# Altium schematic sheet Power.SchDoc(Power)
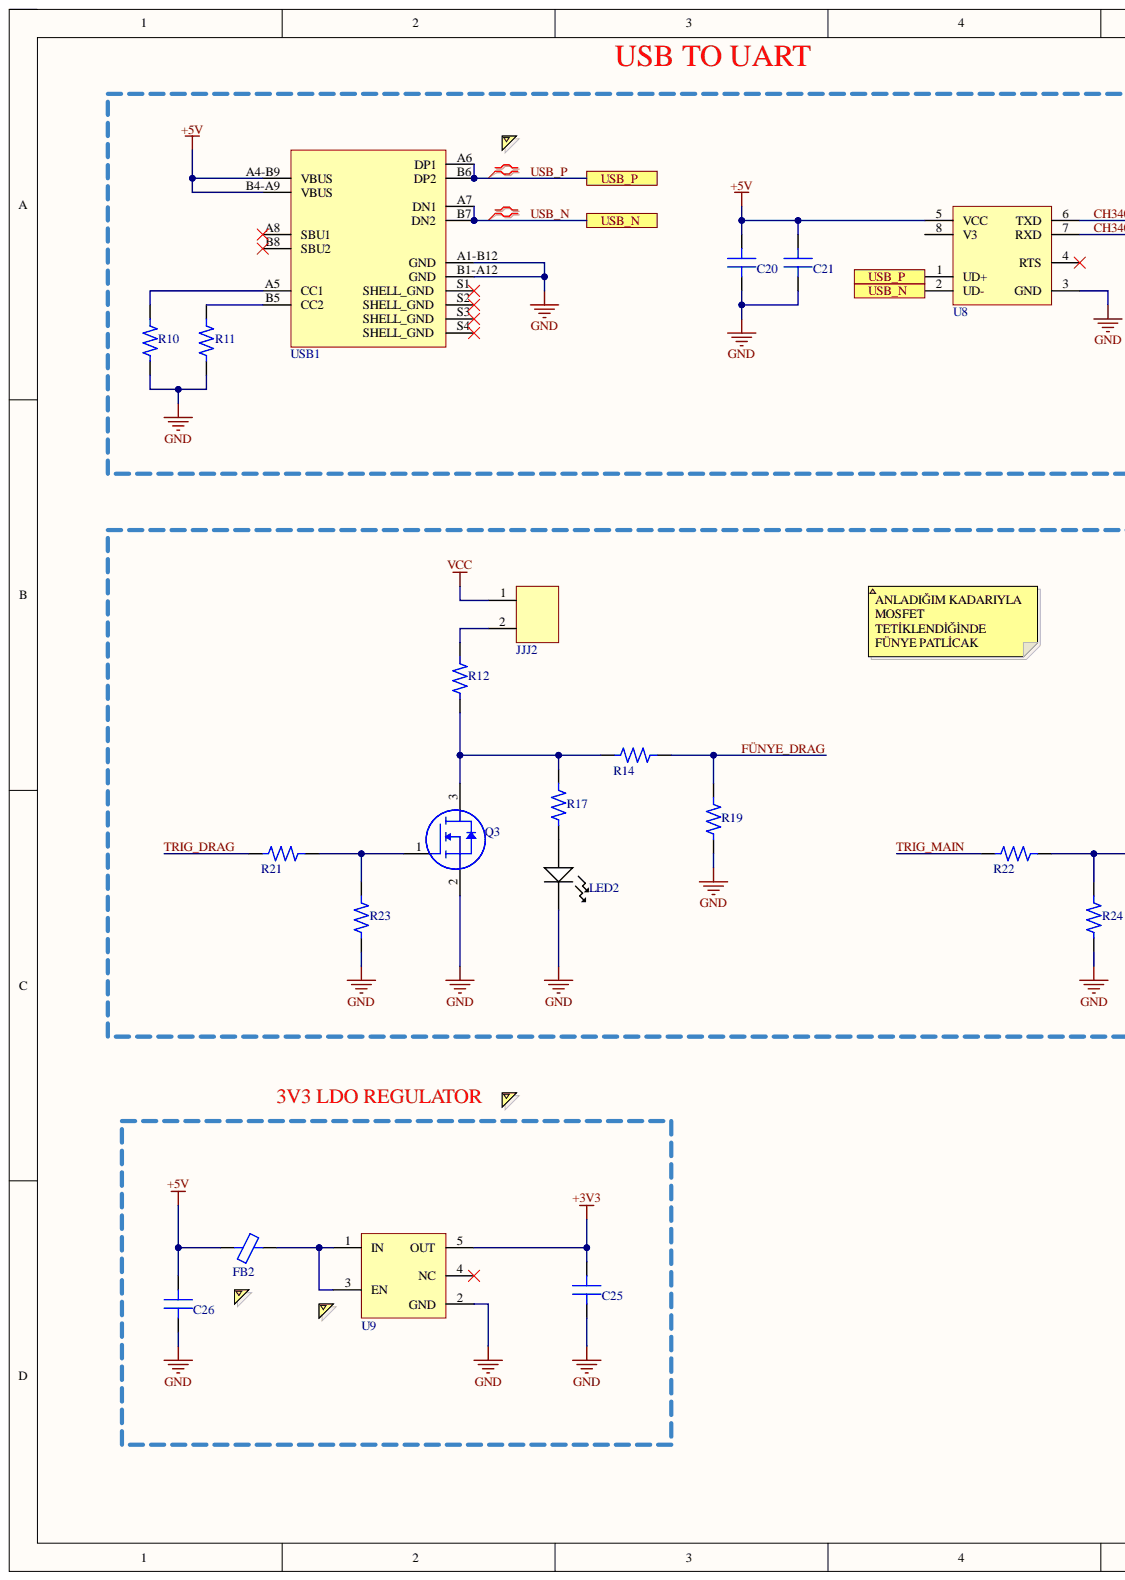
[diagram p0: Power.SchDoc, left]
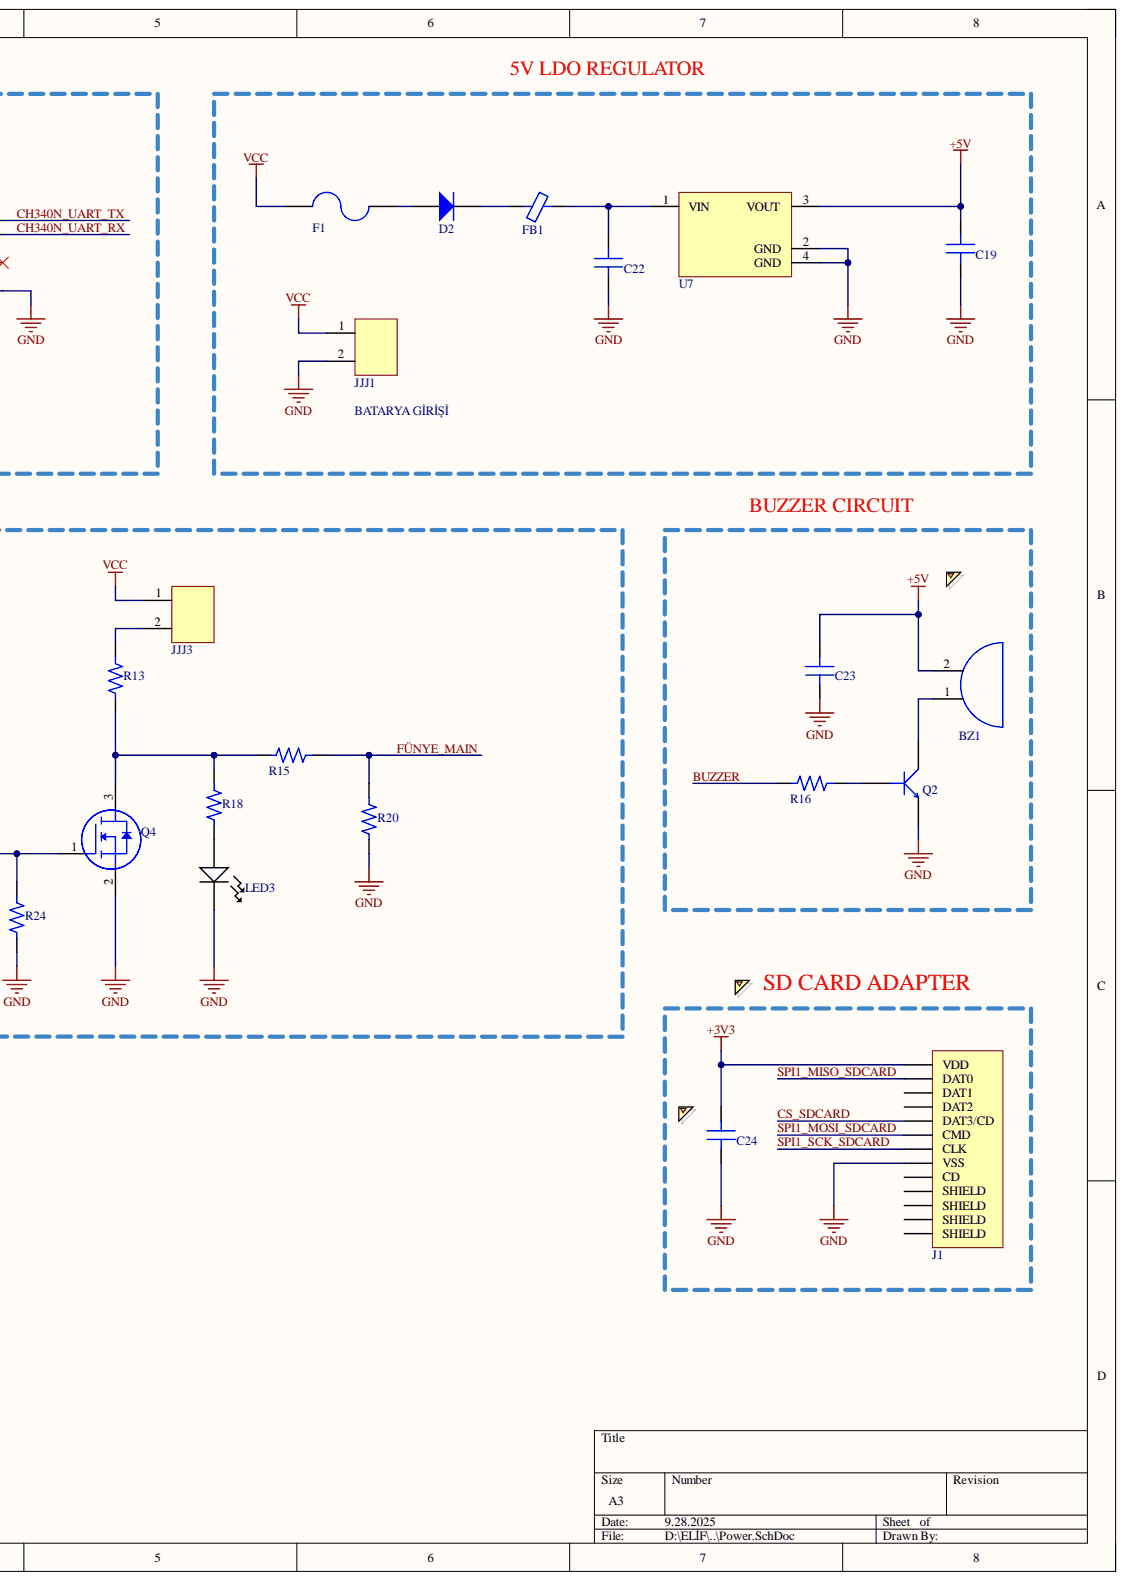
[diagram p1: Power.SchDoc, right]

## Parts
BZ1  CMI-9605IC-0580T  [BUZZER]  (value not drawn)
C19  CAP CER 10uF 0805  [CAP_0805]  (value not drawn)
C20  CAP CER 100nF 0805  [CAP_0805]  (value not drawn)
C21  CAP CER 100nF 0805  [CAP_0805]  (value not drawn)
C22  CAP CER 10uF 0805  [CAP_0805]  (value not drawn)
C23  CAP CER 100nF 0805  [CAP_0805]  (value not drawn)
C24  CAP CER 100nF 0805  [CAP_0805]  (value not drawn)
C25  CAP CER 1uF 0805  [CAP_0805]  (value not drawn)
C26  CAP CER 1uF 0805  [CAP_0805]  (value not drawn)
D2  DIODE GEN PURP 75V 150MA SOD323F  [SOD323F]  (value not drawn)
F1  FUSE 3413.0216.22  [FUSE_1206]  (value not drawn)
FB1  MPZ2012S601AT000  [FERRITE BEAD_0805]  (value not drawn)
FB2  MPZ2012S601AT000  [FERRITE BEAD_0805]  (value not drawn)
J1  MEM2075-00-140-01-A  [SD CARD]  (value not drawn)
JJJ1  HEADER x2  [HEADER x2]  (value not drawn)
JJJ2  HEADER x2  [HEADER x2]  (value not drawn)
JJJ3  HEADER x2  [HEADER x2]  (value not drawn)
LED2  CMD17-21VRD/TR8  [LED RED_0805]  (value not drawn)
LED3  CMD17-21VRD/TR8  [LED RED_0805]  (value not drawn)
Q2  MMBT3904-7-F  [SOT_23F]  (value not drawn)
Q3  NTR5103NT1G  [SOT-23-3]  (value not drawn)
Q4  NTR5103NT1G  [SOT-23-3]  (value not drawn)
R10  RES 10K OHM 0805  [RES_0805]  (value not drawn)
R11  RES 10K OHM 0805  [RES_0805]  (value not drawn)
R12  RES 0 OHM 0805  [RES_0805]  (value not drawn)
R13  RES 0 OHM 0805  [RES_0805]  (value not drawn)
R14  RES 20K OHM 0805  [RES_0805]  (value not drawn)
R15  RES 20K OHM 0805  [RES_0805]  (value not drawn)
R16  RES 100 OHM 0805  [RES_0805]  (value not drawn)
R17  RES 470 OHM 0805  [RES_0805]  (value not drawn)
R18  RES 470 OHM 0805  [RES_0805]  (value not drawn)
R19  RES 10K OHM 0805  [RES_0805]  (value not drawn)
R20  RES 10K OHM 0805  [RES_0805]  (value not drawn)
R21  RES 1K OHM 0805  [RES_0805]  (value not drawn)
R22  RES 1K OHM 0805  [RES_0805]  (value not drawn)
R23  RES 10K OHM 0805  [RES_0805]  (value not drawn)
R24  RES 10K OHM 0805  [RES_0805]  (value not drawn)
U7  MCP1825S-5002E/DB  [SOT-223-3]  (value not drawn)
U8  CH340N  [SOP-8]
U9  NCP161ASN330T1G  [SOT-23-5]  (value not drawn)
USB1  USB4125-GF-A  [USB4125-GF-A / Type C USB]  (value not drawn)

## Ports
USB_N, USB_P

## Nets
+3V3: C24.2 C25.2 J1.P4(VDD) U9.5(OUT)
+5V: BZ1.2 C19.2 C20.2 C21.2 C23.2 C26.2 FB2.1 U7.3(VOUT) U8.5(VCC) USB1.A4-B9(VBUS) USB1.B4-A9(VBUS)
GND: C19.1 C20.1 C21.1 C22.1 C23.1 C24.1 C25.1 C26.1 J1.P6(VSS) JJJ1.2 LED2.2 LED3.2 Q2.2 Q3.2 Q4.2 R10.1 R11.1 R19.1 R20.1 R23.1 R24.1 U7.2(GND) U7.4(GND) U8.3(GND) U9.2(GND) USB1.A1-B12(GND) USB1.B1-A12(GND)
VCC: F1.1 JJJ1.1 JJJ2.1 JJJ3.1
BUZZER: R16.1
CH340N_UART_RX: U8.7(RXD)
CH340N_UART_TX: U8.6(TXD)
CS_SDCARD: J1.P2(DAT3/CD)
FÜNYE_DRAG: R14.2 R19.2
FÜNYE_MAIN: R15.2 R20.2
SPI1_MISO_SDCARD: J1.P7(DAT0)
SPI1_MOSI_SDCARD: J1.P3(CMD)
SPI1_SCK_SDCARD: J1.P5(CLK)
TRIG_DRAG: R21.1
TRIG_MAIN: R22.1
USB_N: U8.2(UD-) USB1.A7(DN1) USB1.B7(DN2) ; port USB_N
USB_P: U8.1(UD+) USB1.A6(DP1) USB1.B6(DP2) ; port USB_P
NetBZ1_1: BZ1.1 Q2.3
NetC22_2: C22.2 FB1.2 U7.1(VIN)
NetD2_1: D2.1 FB1.1
NetD2_2: D2.2 F1.2
NetFB2_2: FB2.2 U9.1(IN) U9.3(EN)
NetJJJ2_2: JJJ2.2 R12.2
NetJJJ3_2: JJJ3.2 R13.2
NetLED2_1: LED2.1 R17.1
NetLED3_1: LED3.1 R18.1
NetQ2_1: Q2.1 R16.2
NetQ3_1: Q3.1 R21.2 R23.2
NetQ3_3: Q3.3 R12.1 R14.1 R17.2
NetQ4_1: Q4.1 R22.2 R24.2
NetQ4_3: Q4.3 R13.1 R15.1 R18.2
NetR10_2: R10.2 USB1.A5(CC1)
NetR11_2: R11.2 USB1.B5(CC2)

Not connected: J1 P1(DAT2) P8(DAT1) P9(CD) SH1(SHIELD) SH2(SHIELD) SH3(SHIELD) SH4(SHIELD); U8 4(RTS) 8(V3); U9 4(NC); USB1 A8(SBU1) B8(SBU2) S1(SHELL_GND) S2(SHELL_GND) S3(SHELL_GND) S4(SHELL_GND)

## Library data of the parts
BZ1 = BUZZER: Audio Buzzer Magnetic 3VDC 7VDC 30mA 5VDC 85dB 2600Hz to 2800Hz Through Hole Pin Box; Manufacturer: CUI Devices; Category: Audio Products; Component Kind: Standard; Manufacturer Part Number: CMI-9605IC-0580T; Pricing 1: 1=1,43000, 10=1,19000, 25=1,10160, 50=1,03700, 100=0,97450, 500=0,83848, 1000=0,78382, 5000=0,76810 (USD); RoHS: No; Stock 1: 6842; Supplier 1: Digi-Key; Supplier Part Number 1: 2223-CMI-9605IC-0580T-ND; Library Name: ROKET_LIBRARY.IntLib
D2 = DIODE: VR=75V IF=150mA Trr=4ns P=200mW; Manufacturer: ON Semiconductor / Fairchild; Manufacturer Part Number: 1N4148WS; Element Configuration: Single; Forward Voltage: 1V; Max Forward Surge Current (Ifsm): 2A; Max Reverse Current: 5uA; Power Dissipation: 200mW; Recovery Time: 4ns; Average Rectified Current: 150mA; Category: Rectifier Diodes; Component Kind: Standard; ComponentLink1Description: https://datasheet.ciiva.com/30479/0900766b810e1cd3-30479206.pdf?src-supplier=Rs; ComponentLink1URL: https://datasheet.ciiva.com/30479/0900766b810e1cd3-30479206.pdf?src-supplier=Rs; ComponentLink2Description: https://datasheet.ciiva.com/15208/getdatasheetpartid-127819-15208358.pdf?src-supplier=Verical; ComponentLink2URL: https://datasheet.ciiva.com/15208/getdatasheetpartid-127819-15208358.pdf?src-supplier=Verical; ComponentLink3Description: https://datasheet.ciiva.com/8300/1n914bws-8300360.pdf?src-supplier=Avnet; ComponentLink3URL: https://datasheet.ciiva.com/8300/1n914bws-8300360.pdf?src-supplier=Avnet; ComponentLink4Description: https://datasheet.ciiva.com/910/1n4148ws-910381.pdf?src-supplier=Digi-Key; ComponentLink4URL: https://datasheet.ciiva.com/910/1n4148ws-910381.pdf?src-supplier=Digi-Key; ComponentLink5Description: https://datasheet.ciiva.com/910/1n4148ws-910382.pdf?src-supplier=Digi-Key; ComponentLink5URL: https://datasheet.ciiva.com/910/1n4148ws-910382.pdf?src-supplier=Digi-Key; ComponentLink6Description: https://datasheet.ciiva.com/910/1n4148ws-910380.pdf?src-supplier=Digi-Key; ComponentLink6URL: https://datasheet.ciiva.com/910/1n4148ws-910380.pdf?src-supplier=Digi-Key; Contact Plating: Tin,Matte; Forward Current: 150mA; Height: 1mm; Lead Free: Lead Free; Length: 1.8mm; Max Operating Temperature: 150°C; Max Repetitive Reverse Voltage (Vrrm): 75V; Max Reverse Voltage (DC): 75V; Min Operating Temperature: -55°C; Mount: Surface Mount; Number of Pins: 2; Package Quantity: 1; Packaging: Tape and Reel; Pricing 1: 1=0,21000, 10=0,19200, 100=0,10460, 500=0,06436, 1000=0,04388 (USD); Radiation Hardening: No; Reach SVHC Compliant: Yes; Reverse Recovery Time: 4ns; Reverse Voltage: 75V; RoHS: Yes; RoHS Compliant: Yes; Series: -; Stock 1: 973654; Supplier 1: Digi-Key; Supplier Part Number 1: 1N4148WSFSDKR-ND; Weight: 0.0276g; Width: 1.35mm; Library Name: ROKET_LIBRARY.IntLib
F1 = FUSE: Fuse Chip Fast Acting 1A 32V SMD Solder Pad 1206 Glass T/R VDE/cULus; Manufacturer: Schurter; Approvals: VDE,cURus; Body Material: Glass,Epoxy; DC Cold Resistance: 94.2mR; Fuse Type: Board Mount (Cartridge Style Excluded); Max Voltage Rating (DC): 63V; Case Code (Metric): 3216; Case Code (Imperial): 1206; Case/Package: 1206; Category: Fuses; Component Kind: Standard; ComponentLink1Description: https://datasheet.ciiva.com/301/typ-usi-1206-301440.pdf?src-supplier=Digi-Key; ComponentLink1URL: https://datasheet.ciiva.com/301/typ-usi-1206-301440.pdf?src-supplier=Digi-Key; ComponentLink2Description: https://datasheet.ciiva.com/14541/70160006-14541114.pdf?src-supplier=Allied+Electronics; ComponentLink2URL: https://datasheet.ciiva.com/14541/70160006-14541114.pdf?src-supplier=Allied+Electronics; ComponentLink3Description: https://datasheet.ciiva.com/301/typ-usi-1206-301439.pdf?src-supplier=Digi-Key; ComponentLink3URL: https://datasheet.ciiva.com/301/typ-usi-1206-301439.pdf?src-supplier=Digi-Key; ComponentLink4Description: https://datasheet.ciiva.com/301/typ-usi-1206-301441.pdf?src-supplier=Digi-Key; ComponentLink4URL: https://datasheet.ciiva.com/301/typ-usi-1206-301441.pdf?src-supplier=Digi-Key; ComponentLink5Description: https://datasheet.ciiva.com/8264/typ-usi-1206-8264583.pdf?src-supplier=Avnet; ComponentLink5URL: https://datasheet.ciiva.com/8264/typ-usi-1206-8264583.pdf?src-supplier=Avnet; ComponentLink6Description: https://datasheet.ciiva.com/14102/qousi1206-data-e-14102903.pdf?src-supplier=Distrelec; ComponentLink6URL: https://datasheet.ciiva.com/14102/qousi1206-data-e-14102903.pdf?src-supplier=Distrelec; ComponentLink7Description: https://datasheet.ciiva.com/16316/typ-usi-1206-16316588.pdf?src-supplier=Future+Electronics; ComponentLink7URL: https://datasheet.ciiva.com/16316/typ-usi-1206-16316588.pdf?src-supplier=Future+Electronics; Contact Plating: Gold; Current Rating: 1A; Depth: 1.6mm; Height: 0.6mm; Housing Material: Glass; Lead Free: Lead Free; Length: 3.2mm; Manufacturer Part Number: 3413.0216.22; Max Operating Temperature: 90°C; Max Voltage Rating (AC): 32V; Min Operating Temperature: -55°C; Mount: Surface Mount,PCB; Number of Pins: 2; Package Quantity: 1000; Packaging: Tape and Reel; Pricing 1: 1=0,67000, 50=0,52400, 100=0,39700, 500=0,32624 (USD); Radiation Hardening: No; RoHS: Yes; RoHS Compliant: Yes; Series: USI 1206; Stock 1: 6832; Supplier 1: Digi-Key; Supplier Part Number 1: 486-1144-1-ND; Terminal Material: Tin; Termination: SMD/SMT; Voltage Rating: 32V; Voltage Rating (AC): 32V; Voltage Rating (DC): 63V; Weight: 0.000212oz; Width: 1.6mm; Working Voltage: 32V; Library Name: ROKET_LIBRARY.IntLib
FB1 FB2 = FERRITE BEAD: 0805 600Ω ±25% DCR=0.1Ω 2A; Manufacturer: TDK; DC Resistance (DCR): 100mR; Number of Lines: 1; Impedance: 600R; Case Code (Metric): 2012; Case Code (Imperial): 0805; Case/Package: 0805; Category: Ferrite Beads and Chips; Component Kind: Standard; ComponentLink1Description: https://datasheet.ciiva.com/46634/beads-commercial-power-mpz-en-46634335.pdf?src-supplier=Digi-Key; ComponentLink1URL: https://datasheet.ciiva.com/46634/beads-commercial-power-mpz-en-46634335.pdf?src-supplier=Digi-Key; ComponentLink2Description: https://datasheet.ciiva.com/46634/beads-commercial-power-mpz-en-46634377.pdf?src-supplier=Digi-Key; ComponentLink2URL: https://datasheet.ciiva.com/46634/beads-commercial-power-mpz-en-46634377.pdf?src-supplier=Digi-Key; ComponentLink3Description: https://datasheet.ciiva.com/46634/beads-commercial-power-mpz-en-46634606.pdf?src-supplier=Digi-Key; ComponentLink3URL: https://datasheet.ciiva.com/46634/beads-commercial-power-mpz-en-46634606.pdf?src-supplier=Digi-Key; Current Rating: 2A; Height: 0.041inch; Lead Free: Lead Free; Length: 0.079inch; Manufacturer Part Number: MPZ2012S601AT000; Max Current Rating: 2A; Max DC Current: 2A; Max Operating Temperature: 125°C; Min Operating Temperature: -55°C; Mount: Surface Mount; Package Quantity: 1; Packaging: Tape and Reel; Pricing 1: 1=0,11000, 10=0,09200, 25=0,08320, 50=0,06100, 100=0,05270, 250=0,04160, 500=0,03512, 1000=0,02957 (USD); Reach SVHC Compliant: Yes; RoHS: Yes; RoHS Compliant: Yes; Series: MPZ; Stock 1: 1942; Supplier 1: Digi-Key; Supplier Part Number 1: 445-MPZ2012S601AT000CT-ND; Width: 0.049inch; Library Name: ROKET_LIBRARY.IntLib
J1 = SD CARD: MEM2075 Series Normally Open SMT Push-Push MicroSD Memory Card Connector; Manufacturer: Global Connector Technology; Category: Connectors; Component Kind: Standard; ComponentLink1Description: https://gct.co/files/drawings/mem2075.pdf?src-supplier=Digi-Key; ComponentLink1URL: https://gct.co/files/drawings/mem2075.pdf?src-supplier=Digi-Key; Manufacturer Part Number: MEM2075-00-140-01-A; Pricing 1: 1=1,64000, 25=1,55000, 75=1,48000, 200=1,34000, 500=1,24000 (EUR); RoHS: No; Stock 1: 1317; Supplier 1: Farnell; Supplier Part Number 1: 2895610; Library Name: ROKET_LIBRARY.IntLib
JJJ1-JJJ3 = HEADER x2: Conn Screw Type Terminal Block 2 POS 3.5mm Solder ST Thru-Hole 10A; Manufacturer: On-Shore Technology; Manufacturer Part Number: OSTTE020104; Number of Levels: 1; Number of Positions Per Level: 2; Pitch: 0.138inch; Plating: Steel; Voltage: 125V; Wire Gauge (Max): 26AWG; Wire Gauge (Min): 16AWG; Category: Terminal Blocks; Color: Black; Component Kind: Standard; ComponentLink1Description: http://www.on-shore.com/wp-content/uploads/2015/09/osttexx0164.pdf?src-supplier=Digi-Key; ComponentLink1URL: http://www.on-shore.com/wp-content/uploads/2015/09/osttexx0164.pdf?src-supplier=Digi-Key; ComponentLink2Description: http://www.on-shore.com/wp-content/uploads/2015/09/osttexx0164.pdf?src-supplier=Verical; ComponentLink2URL: http://www.on-shore.com/wp-content/uploads/2015/09/osttexx0164.pdf?src-supplier=Verical; ComponentLink3Description: http://www.on-shore.com/wp-content/uploads/2015/09/osttexx0164.pdf?src-supplier=Arrow+Electronics; ComponentLink3URL: http://www.on-shore.com/wp-content/uploads/2015/09/osttexx0164.pdf?src-supplier=Arrow+Electronics; Contact Plating: Tin; Current: 10A; Housing Material: Thermoplastic; Max Operating Temperature: 105°C; Min Operating Temperature: -30°C; Mount: Through Hole; Number of Pins: 2; Packaging: Bulk; Pricing 1: 1=0,74000, 10=0,40200, 25=0,30040, 50=0,26780, 100=0,26260, 250=0,23108, 500=0,21008, 1000=0,18907, 5000=0,14180 (USD); RoHS: Yes; Stock 1: 73362; Supplier 1: Digi-Key; Supplier Part Number 1: ED2740-ND; Termination: Screw; Library Name: Roket_Library.SchLib
LED2 LED3 = LED RED: LED Uni-Color Red 640nm 2-Pin SMT T/R; Manufacturer: VCC CHML; Forward Current: 20mA; Forward Voltage: 2V; Lens Style: Diffused,Tinted,Rectangular,Colored; Luminous Intensity: 6mcd; Number of LEDs: 1; Power Rating: 100mW; Reverse Voltage: 5V; Viewing Angle: 140°; Case Code (Metric): 2012; Case Code (Imperial): 0805; Case/Package: 0805; Category: LEDs; Color: Red; Component Kind: Standard; ComponentLink1Description: http://www.mouser.com/ds/2/423/CMD1721ver1-1064576.pdf?src-supplier=Mouser; ComponentLink1URL: http://www.mouser.com/ds/2/423/CMD1721ver1-1064576.pdf?src-supplier=Mouser; ComponentLink2Description: https://www.alliedelec.com/m/d/ea8f758becbd32522d2612194452bcae.pdf?src-supplier=Allied+Electronics; ComponentLink2URL: https://www.alliedelec.com/m/d/ea8f758becbd32522d2612194452bcae.pdf?src-supplier=Allied+Electronics; ComponentLink3Description: http://www.onlinecomponents.com/productFiles/mf-CM/2cmd17-21seriessmtleds0805packagesize.pdf?src-supplier=Onlinecomponents.com; ComponentLink3URL: http://www.onlinecomponents.com/productFiles/mf-CM/2cmd17-21seriessmtleds0805packagesize.pdf?src-supplier=Onlinecomponents.com; ComponentLink4Description: https://vcclite.com/wp-content/uploads/wpallimport/files/files/CMD1721Seriesver3.pdf?src-supplier=Digi-Key; ComponentLink4URL: https://vcclite.com/wp-content/uploads/wpallimport/files/files/CMD1721Seriesver3.pdf?src-supplier=Digi-Key; Height: 1.1mm; Lead Free: Lead Free; Length: 2mm; Lens Color: Red; Manufacturer Part Number: CMD17-21VRD/TR8; Max Operating Temperature: 85°C; Min Operating Temperature: -40°C; Mount: Surface Mount; Number of Pins: 2; Package Quantity: 1; Packaging: Tape and Reel; Pricing 1: 1=0,73000, 10=0,47800, 100=0,31310, 1000=0,24720 (USD); Reverse Voltage (DC): 5V; RoHS: Yes; RoHS Compliant: Yes; Stock 1: 357228; Supplier 1: Digi-Key; Supplier Part Number 1: L62501CT-ND; Test Current: 20mA; Wavelength: 640nm; Width: 1.25mm; Illumination Color: Red; Library Name: ROKET_LIBRARY.IntLib
Q2 = BJT_NPN: NPN Ic=200mA Vceo=40V hfe=100~300 fT=310MHz P=300mW SOT-23; Manufacturer: Diodes Zetex; Collector Base Voltage (VCBO): 60V; Collector Emitter Breakdown Voltage: 40V; Collector Emitter Saturation Voltage: 300mV; Continuous Collector Current: 200mA; Element Configuration: Single; Emitter Base Voltage (VEBO): 6V; Frequency: 300MHz; hFE Min: 100; Max Power Dissipation: 300mW; Number of Elements: 1; Power Dissipation: 350mW; Case/Package: SOT-23; Category: BJTs; Collector Emitter Voltage (VCEO): 40V; Collector-emitter Voltage-Max: 300mV; Component Kind: Standard; ComponentLink1Description: https://datasheet.ciiva.com/11201/ds30036-11201778.pdf?src-supplier=Avnet; ComponentLink1URL: https://datasheet.ciiva.com/11201/ds30036-11201778.pdf?src-supplier=Avnet; ComponentLink2Description: https://datasheet.ciiva.com/15138/getdatasheetpartid-53265-15138780.pdf?src-supplier=Verical; ComponentLink2URL: https://datasheet.ciiva.com/15138/getdatasheetpartid-53265-15138780.pdf?src-supplier=Verical; ComponentLink3Description: https://datasheet.ciiva.com/22711/1915516-22711750.pdf?src-supplier=Element14; ComponentLink3URL: https://datasheet.ciiva.com/22711/1915516-22711750.pdf?src-supplier=Element14; ComponentLink4Description: https://datasheet.ciiva.com/11198/ds30036-11198999.pdf?src-supplier=Avnet; ComponentLink4URL: https://datasheet.ciiva.com/11198/ds30036-11198999.pdf?src-supplier=Avnet; ComponentLink5Description: https://datasheet.ciiva.com/6361/ds30036-6361256.pdf?src-supplier=Digi-Key; ComponentLink5URL: https://datasheet.ciiva.com/6361/ds30036-6361256.pdf?src-supplier=Digi-Key; ComponentLink6Description: https://datasheet.ciiva.com/6361/ds30036-6361254.pdf?src-supplier=Digi-Key; ComponentLink6URL: https://datasheet.ciiva.com/6361/ds30036-6361254.pdf?src-supplier=Digi-Key; Contact Plating: Tin,Matte; Gain Bandwidth Product: 300MHz; Height: 1mm; Lead Free: Lead Free; Length: 3.05mm; Manufacturer Part Number: MMBT3904-7-F; Max Breakdown Voltage: 40V; Max Collector Current: 200mA; Max Frequency: 300MHz; Max Operating Temperature: 150°C; Min Operating Temperature: -55°C; Mount: Surface Mount; Number of Pins: 3; Package Quantity: 3000; Packaging: Tape and Reel; Polarity: NPN; Pricing 1: 1=0,13000, 10=0,11700, 100=0,06370, 500=0,03918, 1000=0,02671 (USD); Radiation Hardening: No; Reach SVHC Compliant: Yes; RoHS: Yes; RoHS Compliant: Yes; Series: Automotive, AEC-Q101; Stock 1: 7729144; Supplier 1: Digi-Key; Supplier Part Number 1: MMBT3904-FDICT-ND; Transition Frequency: 300MHz; Voltage Rating (DC): 40V; Weight: 0.000282oz; Width: 1.4mm; Library Name: ROKET_LIBRARY.IntLib
Q3 Q4 = MOSFET N-Channel: MOSFET N-CH 60V 0.26A SOT23; Manufacturer: ON Semiconductor; Continuous Drain Current (ID): 310mA; Drain to Source Resistance: 1R; Fall Time: 3.6ns; FET Type: 1 N-Channel; Gate to Source Voltage (Vgs): 30V; Max Power Dissipation: 300mW; Number of Channels: 1; Rds On Max: 2.5R; Rise Time: 1.2ns; Turn-Off Delay Time: 4.8ns; Turn-On Delay Time: 1.7ns; Input Capacitance: 40pF; Case/Package: SOT-23-3; Category: MOSFETs; Component Kind: Standard; ComponentLink1Description: http://www.farnell.com/datasheets/2237046.pdf?src-supplier=Farnell; ComponentLink1URL: http://www.farnell.com/datasheets/2237046.pdf?src-supplier=Farnell; ComponentLink2Description: http://www.mouser.com/ds/2/308/NTR5103N-D-600006.pdf?src-supplier=Mouser; ComponentLink2URL: http://www.mouser.com/ds/2/308/NTR5103N-D-600006.pdf?src-supplier=Mouser; ComponentLink3Description: http://www.farnell.com/datasheets/2237046.pdf?src-supplier=Newark; ComponentLink3URL: http://www.farnell.com/datasheets/2237046.pdf?src-supplier=Newark; ComponentLink4Description: http://www.farnell.com/datasheets/2237046.pdf?src-supplier=Element14; ComponentLink4URL: http://www.farnell.com/datasheets/2237046.pdf?src-supplier=Element14; ComponentLink5Description: http://www.onsemi.com/pub/Collateral/NTR5103N-D.PDF?src-supplier=Avnet; ComponentLink5URL: http://www.onsemi.com/pub/Collateral/NTR5103N-D.PDF?src-supplier=Avnet; ComponentLink6Description: http://www.onsemi.com/pub/Collateral/NTR5103N-D.PDF?src-supplier=Digi-Key; ComponentLink6URL: http://www.onsemi.com/pub/Collateral/NTR5103N-D.PDF?src-supplier=Digi-Key; Contact Plating: Tin; Drain to Source Breakdown Voltage: 60V; Drain to Source Voltage (Vdss): 60V; Lead Free: Lead Free; Manufacturer Part Number: NTR5103NT1G; Max Operating Temperature: 150°C; Min Operating Temperature: -55°C; Mount: Surface Mount; Number of Pins: 3; Package Quantity: 3000; Packaging: Tape and Reel; Pricing 1: 1=0,25000, 10=0,20100, 100=0,10660, 500=0,07012, 1000=0,04768 (USD); RoHS: Yes; RoHS Compliant: Yes; Stock 1: 55356; Supplier 1: Digi-Key; Supplier Part Number 1: NTR5103NT1GOSCT-ND; Library Name: ROKET_LIBRARY.IntLib
U7 = MCP1825S-5002E/DB: IC; Linear Regulator; Max. Input; 6V; Output; 5V; 500MA; Accuracy; 0.5%; SOT223-3; Manufacturer: Microchip; Dropout Voltage: 210mV; Min Input Voltage: 2.1V; Number of Outputs: 1; Output Type: Fixed; Polarity: Positive; Quiescent Current: 220uA; Input Bias Current: 120uA; Accuracy: 0.5%; Case/Package: SOT-223; Category: Voltage Regulators - Linear; Component Kind: Standard; ComponentLink1Description: https://datasheet.ciiva.com/41458/001085970-da-01-en-ic-reg-l-mcp1825s-5002e-db-sot-223-3-mcp-41458813.pdf?src-supplier=Conrad; ComponentLink1URL: https://datasheet.ciiva.com/41458/001085970-da-01-en-ic-reg-l-mcp1825s-5002e-db-sot-223-3-mcp-41458813.pdf?src-supplier=Conrad; ComponentLink2Description: https://datasheet.ciiva.com/8041/514217-8041503.pdf?src-supplier=Element14; ComponentLink2URL: https://datasheet.ciiva.com/8041/514217-8041503.pdf?src-supplier=Element14; ComponentLink3Description: https://datasheet.ciiva.com/8239/22056b-8239274.pdf?src-supplier=Avnet; ComponentLink3URL: https://datasheet.ciiva.com/8239/22056b-8239274.pdf?src-supplier=Avnet; ComponentLink4Description: https://datasheet.ciiva.com/16298/filehandler-aspxddocname-en531698-16298391.pdf?src-supplier=Future+Electronics; ComponentLink4URL: https://datasheet.ciiva.com/16298/filehandler-aspxddocname-en531698-16298391.pdf?src-supplier=Future+Electronics; ComponentLink5Description: https://datasheet.ciiva.com/15200/getdatasheetpartid-567689-15200326.pdf?src-supplier=Verical; ComponentLink5URL: https://datasheet.ciiva.com/15200/getdatasheetpartid-567689-15200326.pdf?src-supplier=Verical; ComponentLink6Description: https://datasheet.ciiva.com/2051/22056b-2051733.pdf?src-supplier=Digi-Key; ComponentLink6URL: https://datasheet.ciiva.com/2051/22056b-2051733.pdf?src-supplier=Digi-Key; Height: 1.6mm; Lead Free: Lead Free; Length: 6.5mm; Manufacturer Part Number: MCP1825S-5002E/DB; Max Operating Temperature: 125°C; Max Output Current: 500mA; Max Input Voltage: 6V; Min Operating Temperature: -40°C; Min Output Voltage: 5V; Mount: Surface Mount; Nominal Output Voltage: 5V; Number of Pins: 3; Output Current: 500mA; Output Voltage: 5V; Output Voltage Accuracy: 0.5%; Package Quantity: 78; Packaging: Rail/Tube; Pricing 1: 1=0,69000, 25=0,58320, 100=0,53000 (USD); Radiation Hardening: No; Reach SVHC Compliant: Yes; RoHS: Yes; RoHS Compliant: Yes; Stock 1: 2498; Supplier 1: Digi-Key; Supplier Part Number 1: MCP1825S-5002E/DB-ND; Width: 3.5mm; Library Name: ROKET_LIBRARY.IntLib
U8 = CH340N: USB TO UART TTL CONVERTER - SOP 8 PACKAGE; Component Kind: Standard; Library Name: ROKET_LIBRARY.IntLib
U9 = NCP161ASN330T1G: LDO Regulator, 450 mA, Ultra-High PSRR, Ultra-Low Noise 3.3V, SOT23-5L, Active Discharge; Manufacturer: ON Semiconductor; Current - Output: 450mA; Voltage - Input (Max): 5.5V; Category: Voltage Regulators - Linear; Component Kind: Standard; ComponentLink1Description: http://www.farnell.com/datasheets/2815754.pdf?src-supplier=Farnell; ComponentLink1URL: http://www.farnell.com/datasheets/2815754.pdf?src-supplier=Farnell; Manufacturer Part Number: NCP161ASN330T1G; Pricing 1: 1=0,67000, 10=0,58900, 25=0,55320, 100=0,45160, 250=0,41948, 500=0,35700, 1000=0,28561 (USD); RoHS: Yes; RoHS Compliant: Yes; Stock 1: 4959; Supplier 1: Digi-Key; Supplier Device Package: SOT-23-5; Supplier Part Number 1: NCP161ASN330T1GOSCT-ND; Voltage - Output (Min/Fixed): 3.3V; Library Name: ROKET_LIBRARY.IntLib
USB1 = TYPE C USB CONNECTOR: USB Connector with 1.90mm shell stake length; Manufacturer: Global Connector Technology; Manufacturer Part Number: USB4125-GF-A-0190; Category: USB Connectors; Component Kind: Standard; Pricing 1: 1000=0,40500, 5000=0,39700, 10000=0,38900, 20000=0,38100 (EUR); RoHS: No; Stock 1: 2000; Supplier 1: Farnell; Supplier Part Number 1: 3777666; Library Name: ROKET_LIBRARY.IntLib
C19 C22 = CAP CER 10uF 0805: Component Kind: Standard; Library Name: ROKET_LIBRARY.IntLib
C20 C21 C23 C24 = CAP CER 100nF 0805: Component Kind: Standard; Library Name: ROKET_LIBRARY.IntLib
C25 C26 = CAP CER 1uF 0805: Component Kind: Standard; Library Name: ROKET_LIBRARY.IntLib
R10 R11 R19 R20 R23 R24 = RES 10K OHM 0805: Component Kind: Standard; Library Name: ROKET_LIBRARY.IntLib
R12 R13 = RES 0 OHM 0805: Component Kind: Standard; Library Name: ROKET_LIBRARY.IntLib
R14 R15 = RES 20K OHM 0805: Component Kind: Standard; Library Name: ROKET_LIBRARY.IntLib
R16 = RES 100 OHM 0805: Component Kind: Standard; Library Name: ROKET_LIBRARY.IntLib
R17 R18 = RES 470 OHM 0805: Component Kind: Standard; Library Name: ROKET_LIBRARY.IntLib
R21 R22 = RES 1K OHM 0805: Component Kind: Standard; Library Name: ROKET_LIBRARY.IntLib
